# Altium schematic sheet DM0255_MTP006.SchDoc(DM0255_MTP006)
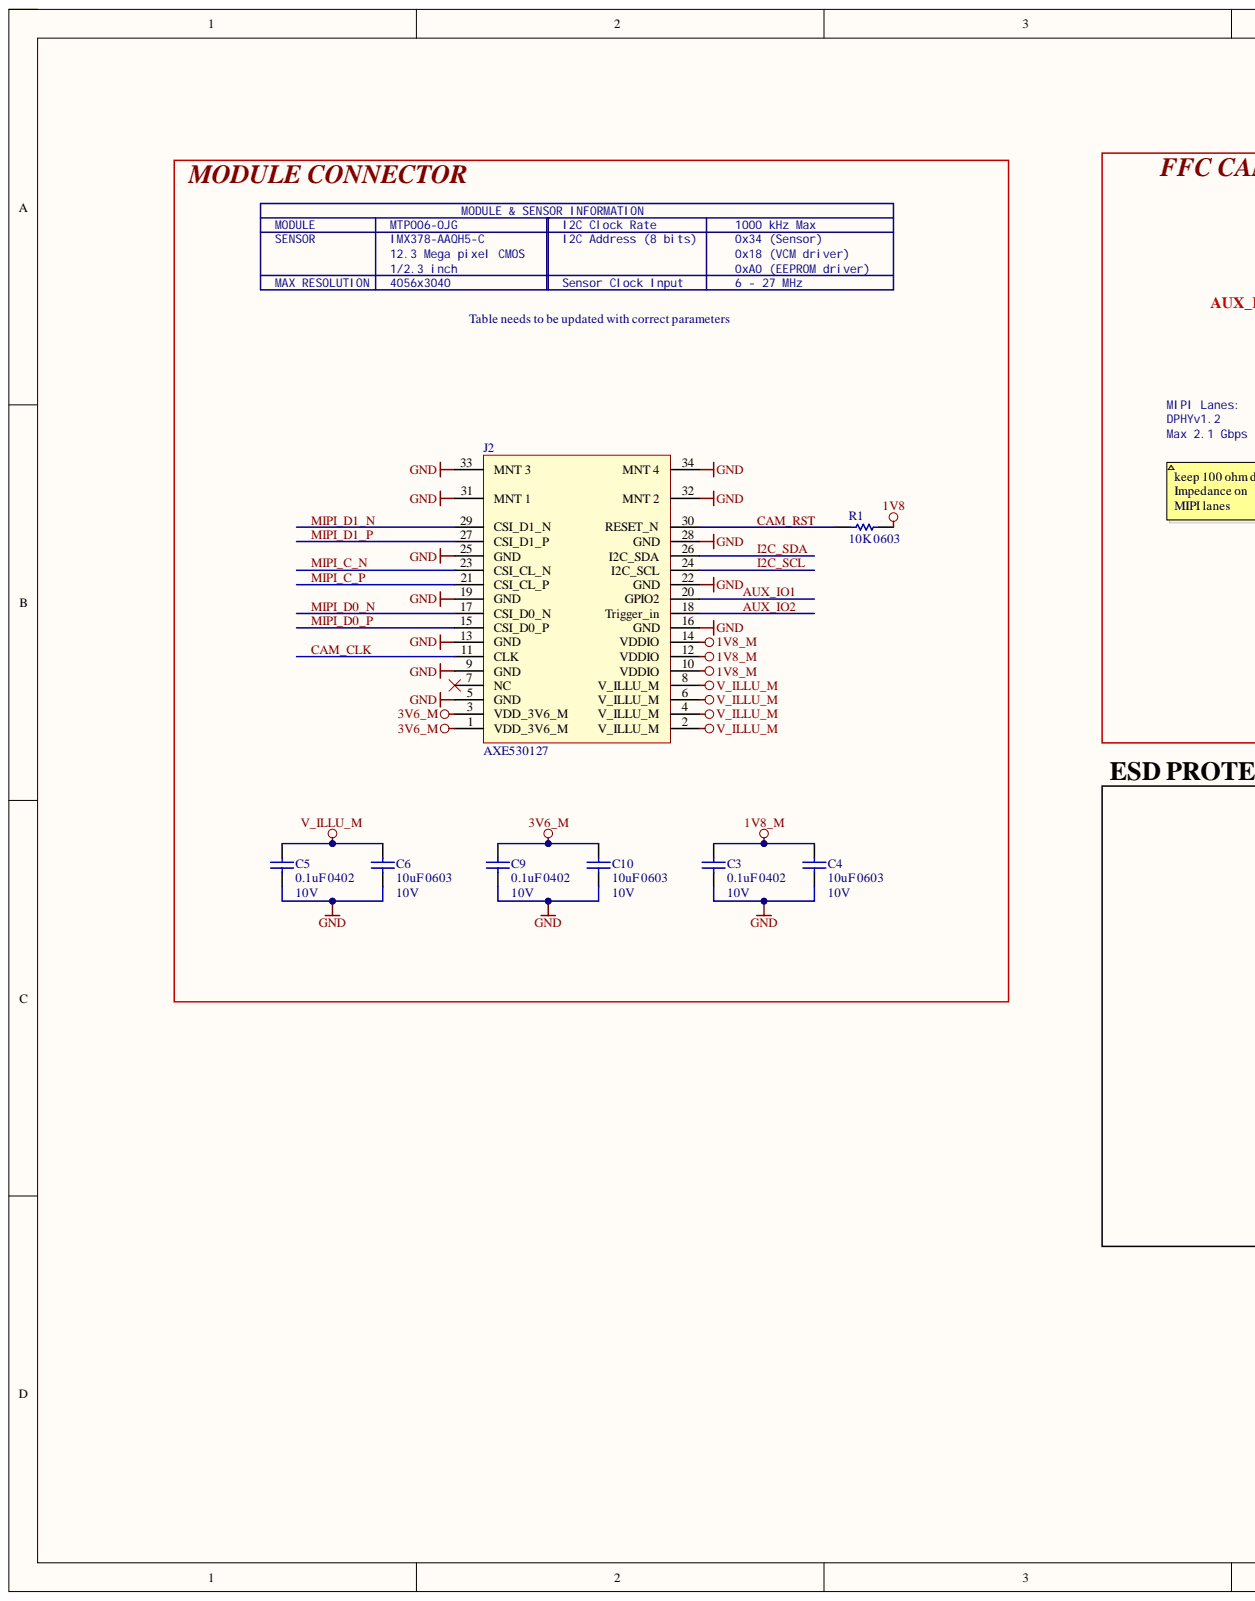
[diagram p0: DM0255_MTP006.SchDoc, left]
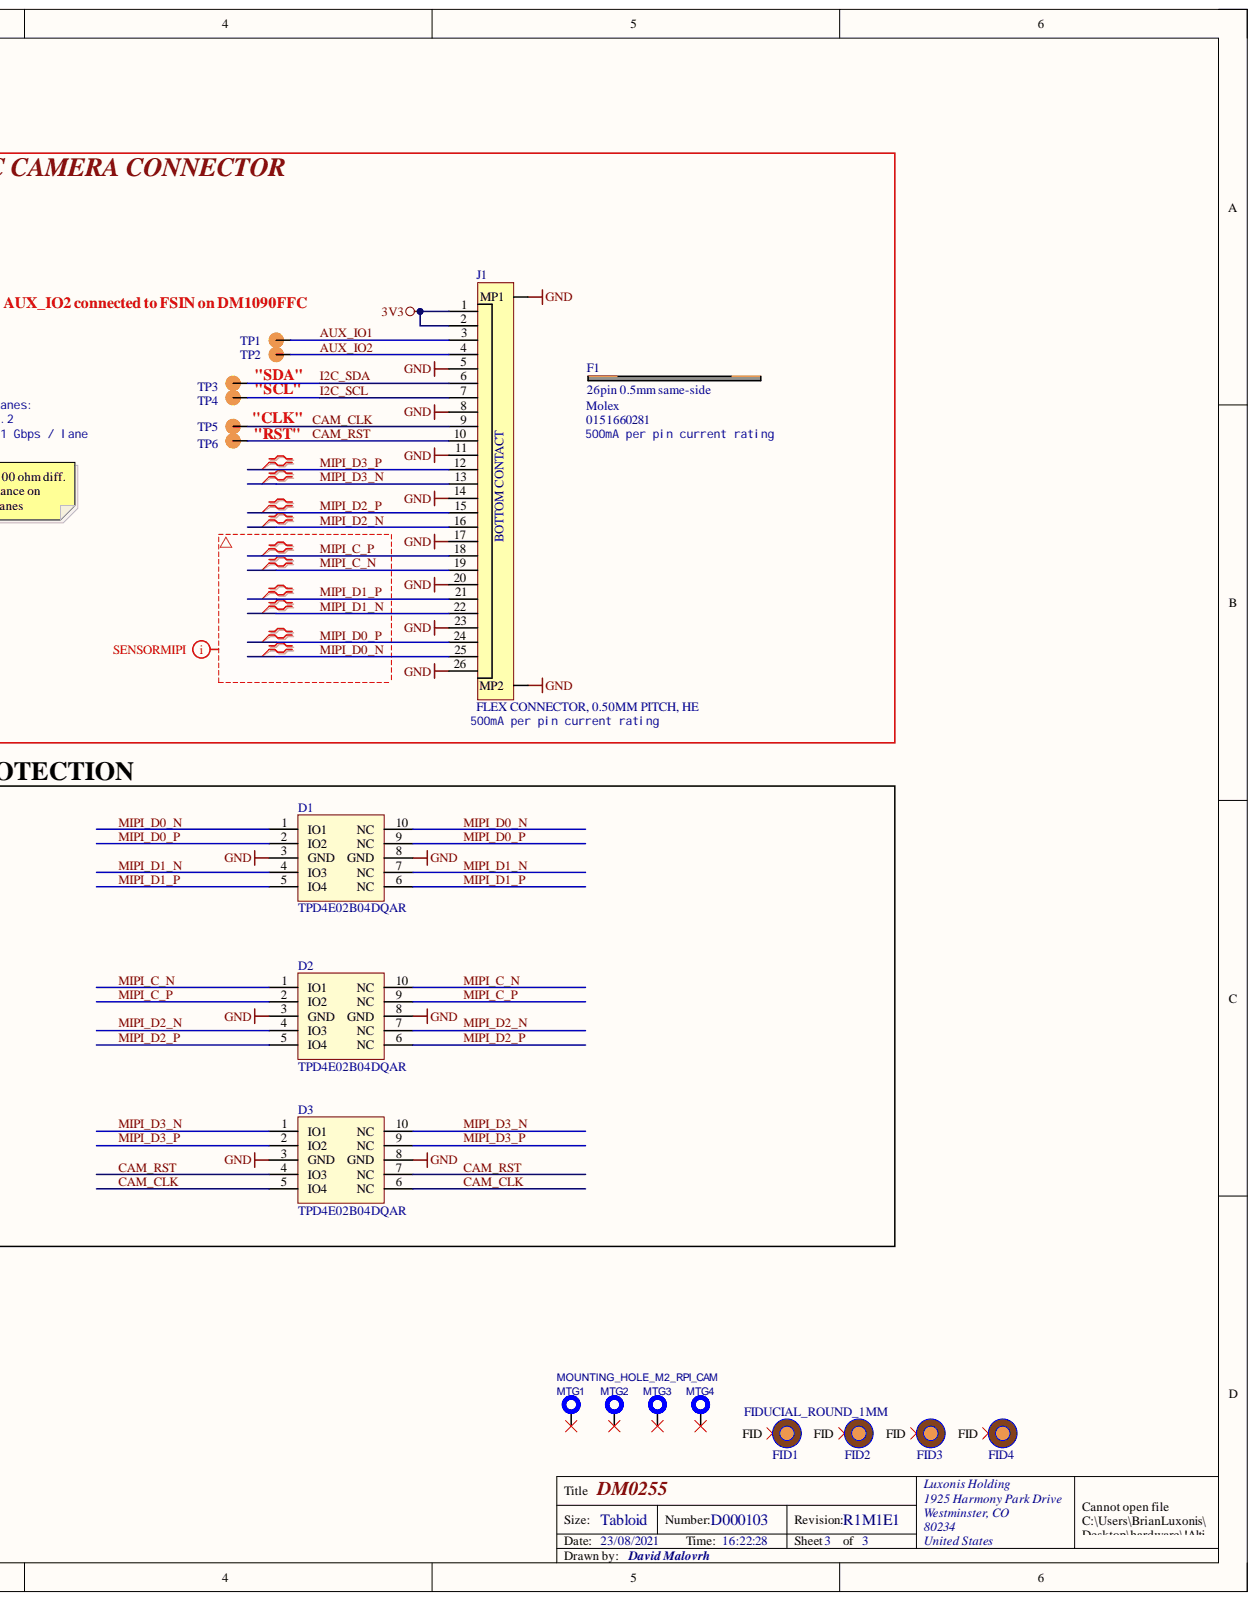
[diagram p1: DM0255_MTP006.SchDoc, right]

## Parts
C3  0.1uF 0402  Voltage Rating: 10V  [CAPC0402(1005)70_N]
C4  10uF 0603  Capacitance: 10uF  Case Code (Imperial): 0603  Voltage Rating: 10V  [CAPC0603(1608)100_N]
C5  0.1uF 0402  Voltage Rating: 10V  [CAPC0402(1005)70_N]
C6  10uF 0603  Capacitance: 10uF  Case Code (Imperial): 0603  Voltage Rating: 10V  [CAPC0603(1608)100_N]
C9  0.1uF 0402  Voltage Rating: 10V  [CAPC0402(1005)70_N]
C10  10uF 0603  Capacitance: 10uF  Case Code (Imperial): 0603  Voltage Rating: 10V  [CAPC0603(1608)100_N]
D1  TPD4E02B04DQAR  [TPD4E02B04DQAR]
D2  TPD4E02B04DQAR  [TPD4E02B04DQAR]
D3  TPD4E02B04DQAR  [TPD4E02B04DQAR]
F1  26pin 0.5mm same-side  Cable Pitch: 0.5mm  Manufacturer 1: Molex  (mechanical)
FID1  FIDUCIAL_ROUND_1MM  [FIDUCIAL_ROUND_1MM]  (no BOM)
FID2  FIDUCIAL_ROUND_1MM  [FIDUCIAL_ROUND_1MM]  (no BOM)
FID3  FIDUCIAL_ROUND_1MM  [FIDUCIAL_ROUND_1MM]  (no BOM)
FID4  FIDUCIAL_ROUND_1MM  [FIDUCIAL_ROUND_1MM]  (no BOM)
J1  FLEX CONNECTOR, 0.50MM PITCH, HE  Current Rating: 500mA  [F32R-1A7H1-11026]
J2  AXE530127  [AXE530127]
MTG1  MOUNTING_HOLE_M2_RPI_CAM  [MOUNTING_HOLE_M2_RPI_CAM]  (no BOM)
MTG2  MOUNTING_HOLE_M2_RPI_CAM  [MOUNTING_HOLE_M2_RPI_CAM]  (no BOM)
MTG3  MOUNTING_HOLE_M2_RPI_CAM  [MOUNTING_HOLE_M2_RPI_CAM]  (no BOM)
MTG4  MOUNTING_HOLE_M2_RPI_CAM  [MOUNTING_HOLE_M2_RPI_CAM]  (no BOM)
R1  10K 0603  Case Code (Imperial): 0603  [RESC0603(1608)_N]
TP1  TP100_200_NS  [TP100_200_NS]  (value not drawn)  (no BOM)
TP2  TP100_200_NS  [TP100_200_NS]  (value not drawn)  (no BOM)
TP3  TP100_200_NS  [TP100_200_NS]  (value not drawn)  (no BOM)
TP4  TP100_200_NS  [TP100_200_NS]  (value not drawn)  (no BOM)
TP5  TP100_200_NS  [TP100_200_NS]  (value not drawn)  (no BOM)
TP6  TP100_200_NS  [TP100_200_NS]  (value not drawn)  (no BOM)

## Nets
1V8: R1.2
1V8_M: C3.2 C4.2 J2.10(VDDIO) J2.12(VDDIO) J2.14(VDDIO)
3V3: J1.1 J1.2
3V6_M: C9.2 C10.2 J2.1(VDD_3V6_M) J2.3(VDD_3V6_M)
GND: C3.1 C4.1 C5.1 C6.1 C9.1 C10.1 D1.3(GND) D1.8(GND) D2.3(GND) D2.8(GND) D3.3(GND) D3.8(GND) J1.5 J1.8 J1.11 J1.14 J1.17 J1.20 J1.23 J1.26 J1.MP1 J1.MP2 J2.5(GND) J2.9(GND) J2.13(GND) J2.16(GND) J2.19(GND) J2.22(GND) J2.25(GND) J2.28(GND) J2.31(MNT_1) J2.32(MNT_2) J2.33(MNT_3) J2.34(MNT_4)
V_ILLU_M: C5.2 C6.2 J2.2(V_ILLU_M) J2.4(V_ILLU_M) J2.6(V_ILLU_M) J2.8(V_ILLU_M)
AUX_IO1: J1.3 J2.20(GPIO2) TP1.1
AUX_IO2: J1.4 J2.18(Trigger_in) TP2.1
CAM_CLK: D3.5(IO4) D3.6(NC) J1.9 J2.11(CLK) TP5.1
CAM_RST: D3.4(IO3) D3.7(NC) J1.10 J2.30(RESET_N) R1.1 TP6.1
I2C_SCL: J1.7 J2.24(I2C_SCL) TP4.1
I2C_SDA: J1.6 J2.26(I2C_SDA) TP3.1
MIPI_C_N: D2.1(IO1) D2.10(NC) J1.19 J2.23(CSI_CL_N)
MIPI_C_P: D2.2(IO2) D2.9(NC) J1.18 J2.21(CSI_CL_P)
MIPI_D0_N: D1.1(IO1) D1.10(NC) J1.25 J2.17(CSI_D0_N)
MIPI_D0_P: D1.2(IO2) D1.9(NC) J1.24 J2.15(CSI_D0_P)
MIPI_D1_N: D1.4(IO3) D1.7(NC) J1.22 J2.29(CSI_D1_N)
MIPI_D1_P: D1.5(IO4) D1.6(NC) J1.21 J2.27(CSI_D1_P)
MIPI_D2_N: D2.4(IO3) D2.7(NC) J1.16
MIPI_D2_P: D2.5(IO4) D2.6(NC) J1.15
MIPI_D3_N: D3.1(IO1) D3.10(NC) J1.13
MIPI_D3_P: D3.2(IO2) D3.9(NC) J1.12

Not connected: FID1 FID; FID2 FID; FID3 FID; FID4 FID; J2 7(NC); MTG1 1; MTG2 1; MTG3 1; MTG4 1

## Library data of the parts
C3 C5 C9 = GRM155R71A104JA01D: CAP CER 0.1UF 10V X7R 0402 5%; Manufacturer 1: Murata; Capacitance: 100nF; Case Code (Imperial): 0402; Case Code (Metric): 1005; Case/Package: 0402; Component Kind: Standard; ComponentLink1Description: https://datasheet.ciiva.com/5411/product-5411135.pdf?src-supplier=Mouser; ComponentLink1URL: https://datasheet.ciiva.com/5411/product-5411135.pdf?src-supplier=Mouser; ComponentLink2Description: https://datasheet.ciiva.com/11618/c02e-11618821.pdf?src-supplier=Avnet; ComponentLink2URL: https://datasheet.ciiva.com/11618/c02e-11618821.pdf?src-supplier=Avnet; Depth: 0.5mm; Dielectric: X7R; Height: 0.5mm; Lead Free: Lead Free; Length: 1mm; Manufacturer Part Number 1: GRM155R71A104JA01D; Max Operating Temperature: 125°C; Min Operating Temperature: -55°C; Mount: Surface Mount; Package Quantity: 10000; Packaging: Tape and Reel; Pricing 1: 1=0.10000, 10=0.02500, 100=0.01130, 500=0.00806, 1000=0.00633, 2500=0.00575, 5000=0.00529 (USD); Radiation Hardening: No; RoHS: Yes; Stock 1: 1346709; Supplier 1: Digi-Key; Supplier Part Number 1: 490-10777-1-ND; Termination: SMD/SMT; Thickness: 0.022inch; Tolerance: 5%; Voltage Rating (DC): 10V; Width: 0.02inch; Library Name: LuxonisMaster.SchLib
C4 C6 C10 = GRM188R61A106ME69D: CAP CER 10UF 10V X5R 0603 20%; Manufacturer 1: Murata; Material: Ceramic; Case Code (Metric): 1608; Case/Package: 0603; Component Kind: Standard; ComponentLink1Description: http://www.mouser.com/ds/2/281/c02e-2905.pdf?src-supplier=Mouser; ComponentLink1URL: http://www.mouser.com/ds/2/281/c02e-2905.pdf?src-supplier=Mouser; ComponentLink2Description: http://components.arrow.com/part/dataview/0323_06119472?src-supplier=Arrow+Europe; ComponentLink2URL: http://components.arrow.com/part/dataview/0323_06119472?src-supplier=Arrow+Europe; ComponentLink3Description: http://www.farnell.com/datasheets/2048014.pdf?src-supplier=Farnell; ComponentLink3URL: http://www.farnell.com/datasheets/2048014.pdf?src-supplier=Farnell; ComponentLink4Description: http://www.farnell.com/datasheets/1872210.pdf?src-supplier=Farnell; ComponentLink4URL: http://www.farnell.com/datasheets/1872210.pdf?src-supplier=Farnell; ComponentLink5Description: http://search.murata.co.jp/Ceramy/image/img/A01X/G101/ENG/GRM188R61A106ME69-01.pdf?src-supplier=Digi-Key; ComponentLink5URL: http://search.murata.co.jp/Ceramy/image/img/A01X/G101/ENG/GRM188R61A106ME69-01.pdf?src-supplier=Digi-Key; ComponentLink6Description: http://www.farnell.com/datasheets/1872210.pdf?src-supplier=Newark; ComponentLink6URL: http://www.farnell.com/datasheets/1872210.pdf?src-supplier=Newark; ComponentLink7Description: http://www.farnell.com/datasheets/2048014.pdf?src-supplier=Element14; ComponentLink7URL: http://www.farnell.com/datasheets/2048014.pdf?src-supplier=Element14; ComponentLink8Description: http://www.farnell.com/datasheets/2048014.pdf?src-supplier=Newark; ComponentLink8URL: http://www.farnell.com/datasheets/2048014.pdf?src-supplier=Newark; Depth: 0.8mm; Dielectric: X5R; Height: 0.8mm; Lead Free: Lead Free; Length: 1.6mm; Manufacturer Part Number 1: GRM188R61A106ME69D; Max Operating Temperature: 85°C; Min Operating Temperature: -55°C; Mount: Surface Mount; Package Quantity: 4000; Packaging: Tape and Reel; Pricing 1: 1=0.21000, 10=0.14100, 100=0.07130, 500=0.04876, 1000=0.04313 (USD); REACH SVHC: Unknown; RoHS: Yes; Stock 1: 2873098; Supplier 1: Digi-Key; Supplier Part Number 1: 490-10475-1-ND; Termination: SMD/SMT; Thickness: 0.035inch; Tolerance: 20%; Voltage Rating (DC): 10V; Weight: 0.000222oz; Width: 0.8mm; Library Name: LuxonisMaster.SchLib
D1-D3 = TPD4E02B04DQAR: TVS DIODE 3.6V 8.8V 10USON 8.8V (Typ) Clamp 5A (8/20µs) Ipp Tvs Diode Surface Mount 10-USON (2.5x1); Manufacturer 1: Texas Instruments; Interface: USB,HDMI; Min Breakdown Voltage: 5.5V; Number of Bidirectional Channels: 4; Peak Pulse Power: 17W; Power Line Protection: No; Clamping Voltage: 8.8V; Component Kind: Standard; ComponentLink1Description: http://www.ti.com/general/docs/lit/getliterature.tsp?genericPartNumber=TPD4E02B04&amp;&amp;fileType=pdf&src-supplier=Element14; ComponentLink1URL: http://www.ti.com/general/docs/lit/getliterature.tsp?genericPartNumber=TPD4E02B04&amp;&amp;fileType=pdf&src-supplier=Element14; ComponentLink2Description: http://www.ti.com/lit/ds/symlink/tpd4e02b04.pdf?src-supplier=Farnell; ComponentLink2URL: http://www.ti.com/lit/ds/symlink/tpd4e02b04.pdf?src-supplier=Farnell; ComponentLink3Description: http://www.ti.com/lit/ds/symlink/tpd4e02b04.pdf?src-supplier=Newark; ComponentLink3URL: http://www.ti.com/lit/ds/symlink/tpd4e02b04.pdf?src-supplier=Newark; ComponentLink4Description: http://www.ti.com/lit/ds/symlink/tpd4e02b04.pdf?src-supplier=Digi-Key; ComponentLink4URL: http://www.ti.com/lit/ds/symlink/tpd4e02b04.pdf?src-supplier=Digi-Key; Composition: Zener; Lead Free: Lead Free; Manufacturer Part Number 1: TPD4E02B04DQAR; Max Operating Temperature: 125°C; Min Operating Temperature: -40°C; Mount: Surface Mount; Number of Channels: 4; Number of Pins: 10; Operating Supply Voltage: 3.6V; Packaging: Cut Tape; Peak Pulse Current: 5A; Pricing 1: 1=0.69000, 10=0.60800, 100=0.46650, 500=0.36874, 1000=0.29499 (USD); REACH SVHC: No SVHC; Reverse Standoff Voltage: 3.6V; RoHS: Yes; Stock 1: 25615; Supplier 1: Digi-Key; Supplier Part Number 1: 296-43875-1-ND; Library Name: LuxonisMaster.SchLib
F1 = 0151660281: CABLE FFC 26POS 0.50MM 6"; Number of Conductors: 26; Pitch: 0.02inch; Wire/Cable Type: Flat Flex; ComponentLink1Description: https://datasheet.ciiva.com/10989/0151660281-cable-10989152.pdf?src-supplier=Avnet; ComponentLink1URL: https://datasheet.ciiva.com/10989/0151660281-cable-10989152.pdf?src-supplier=Avnet; ComponentLink2Description: https://datasheet.ciiva.com/2944/151660051-sd-2944673.pdf?src-supplier=Digi-Key; ComponentLink2URL: https://datasheet.ciiva.com/2944/151660051-sd-2944673.pdf?src-supplier=Digi-Key; Length: 6inch; Library Name: BG0249_R1M1E1.SchLib; Manufacturer Part Number 1: 0151660281; Number of Pins: 26; Package Quantity: 1000; Packaging: Bulk; Pricing 1: 1=2.21000, 10=2.01000, 25=1.88680, 50=1.80460, 100=1.72260, 250=1.55856, 500=1.43552, 1000=1.23045, 2500=1.14842 (USD); Radiation Hardening: No; RoHS: Yes; Stock 1: 118; Supplier 1: Digi-Key; Supplier 2: Mouser; Supplier Part Number 1: WM11334-ND; Supplier Part Number 2: 538-15166-0281
FID1-FID4 = FIDUCIAL: Fiducial with various available footprints; Library Name: LuxonisMaster.SchLib
J1 = F32R-1A7H1-11026: 26 Position FFC, FPC Connector Contacts, Bottom 0.020" (0.50mm) Surface Mount, Right Angle; Manufacturer 1: Amphenol ICC (FCI); Number of Positions: 26; Pitch: 0.02inch; Voltage Rating: 50V; Category: Connectors; Component Kind: Standard; ComponentLink1Description: https://cdn.amphenol-icc.com/media/wysiwyg/files/drawing/f32r-f32j.pdf; ComponentLink1URL: https://cdn.amphenol-icc.com/media/wysiwyg/files/drawing/f32r-f32j.pdf; Contact Material: Bronze; Contact Plating: Tin; Flammability Rating: UL94 V-0; Library Name: DM0255.SCHLIB; Manufacturer Part Number 1: F32R-1A7H1-11026; Max Operating Temperature: 105°C; Min Operating Temperature: -40°C; Mount: Surface Mount; Packaging: Tape and Reel; Pricing 1: 1=0.77, 10=0.721, 100=0.5529, 500=0.4808 (USD); RoHS: Yes; Stock 1: 1709; Supplier 1: Digi-Key; Supplier Part Number 1: 609-F32R-1A7H1-11026CT-ND; Termination: Solder
J2 = AXE530127: Narrow Pitch Connector (Board to FPC) 0.4mm; Manufacturer 1: Panasonic; Connector Type: Socket; Mated Stacking Heights: 1mm; Number of Positions: 30; Pitch: 0.016inch; Category: Headers and Wire Housings; Component Kind: Standard; ComponentLink1Description: http://www.farnell.com/datasheets/2643909.pdf?src-supplier=Farnell; ComponentLink1URL: http://www.farnell.com/datasheets/2643909.pdf?src-supplier=Farnell; ComponentLink2Description: http://www3.panasonic.biz/ac/e_download/control/connector/base-fpc/catalog/con_eng_a4s.pdf?src-supplier=Digi-Key; ComponentLink2URL: http://www3.panasonic.biz/ac/e_download/control/connector/base-fpc/catalog/con_eng_a4s.pdf?src-supplier=Digi-Key; Contact Plating: Gold; Library Name: DM0255.SCHLIB; Manufacturer Part Number 1: AXE530127; Mount: Surface Mount; Number of Pins: 30; Number of Rows: 2; Packaging: Tape and Reel; Pricing 1: 1=1,37000, 10=1,24800, 25=1,17120, 50=1,12020, 100=1,06940, 250=0,96752, 500=0,89114, 1000=0,76383, 2500=0,71291 (USD); RoHS: Yes; RoHS Compliant: Yes; Stock 1: 21082; Supplier 1: Digi-Key; Supplier Part Number 1: 255-5287-1-ND
MTG1-MTG4 = (no symbol name): A - Level: 0; B - Value: Not a Part; Description: MOUNTING_HOLE_M2_RPI_CAM; Library Name: LuxonisMaster.SchLib
R1 = RC0603FR-0710KL: RES SMD 10K OHM 1% 1/10W 0603; Manufacturer 1: Yageo; Power Rating: 100mW; Resistance: 10kR; Temperature Coefficient: 100ppm/°C; Voltage Rating: 75V; Voltage Rating (DC): 50V; Case Code (Metric): 1608; Case/Package: 0603; Component Kind: Standard; ComponentLink1Description: https://datasheet.ciiva.com/7660/38590-7660789.pdf?src-supplier=Element14; ComponentLink1URL: https://datasheet.ciiva.com/7660/38590-7660789.pdf?src-supplier=Element14; ComponentLink2Description: https://datasheet.ciiva.com/15189/getdatasheetpartid-409289-15189591.pdf?src-supplier=Verical; ComponentLink2URL: https://datasheet.ciiva.com/15189/getdatasheetpartid-409289-15189591.pdf?src-supplier=Verical; ComponentLink3Description: https://datasheet.ciiva.com/46440/1868787-46440891.pdf?src-supplier=Element14; ComponentLink3URL: https://datasheet.ciiva.com/46440/1868787-46440891.pdf?src-supplier=Element14; ComponentLink4Description: https://datasheet.ciiva.com/7983/443971-7983596.pdf?src-supplier=Element14; ComponentLink4URL: https://datasheet.ciiva.com/7983/443971-7983596.pdf?src-supplier=Element14; ComponentLink5Description: https://datasheet.ciiva.com/7746/1738695-7746239.pdf?src-supplier=Element14; ComponentLink5URL: https://datasheet.ciiva.com/7746/1738695-7746239.pdf?src-supplier=Element14; ComponentLink6Description: https://datasheet.ciiva.com/22747/443971-22747519.pdf?src-supplier=Element14; ComponentLink6URL: https://datasheet.ciiva.com/22747/443971-22747519.pdf?src-supplier=Element14; Composition: Thick Film; Depth: 0.8mm; Height: 0.45mm; Lead Free: Lead Free; Lead Pitch: 0.3mm; Length: 1.6mm; Manufacturer 2: Yageo; Manufacturer 3: Yageo; Manufacturer Part Number 1: RC0603FR-0710KL; Manufacturer Part Number 2: RC0603FR-0710KL; Manufacturer Part Number 3: RC0603FR-0710KL; Max Operating Temperature: 155°C; Military Standard: Not; Min Operating Temperature: -55°C; Number of Pins: 2; Packaging: Tape and Reel; Pricing 1: 1=0.10000, 10=0.02200, 100=0.00890, 1000=0.00401, 2500=0.00348 (USD); Pricing 2: 1=0.10000, 10=0.02200, 100=0.00890, 1000=0.00401, 2500=0.00348 (USD); Pricing 3: 5000=0.00261, 10000=0.00227, 25000=0.00199, 50000=0.00183, 125000=0.00179 (USD); REACH SVHC: No SVHC; RoHS: Yes; Stock 1: 80414305; Stock 2: 80414305; Stock 3: 80410000; Supplier 1: Digi-Key; Supplier 2: Digi-Key; Supplier 3: Digi-Key; Supplier Part Number 1: 311-10.0KHRCT-ND; Supplier Part Number 2: 311-10.0KHRDKR-ND; Supplier Part Number 3: 311-10.0KHRTR-ND; Termination: SMD/SMT; Tolerance: 1%; Weight: 7.1E-05oz; Width: 0.8mm; Library Name: LuxonisMaster.SchLib
TP1-TP6 = TEST_POINT: Library Name: LuxonisMaster.SchLib
